# Altium schematic sheet PRODUCT_SchematicSheet.SchDoc(PRODUCT_SchematicSheet)
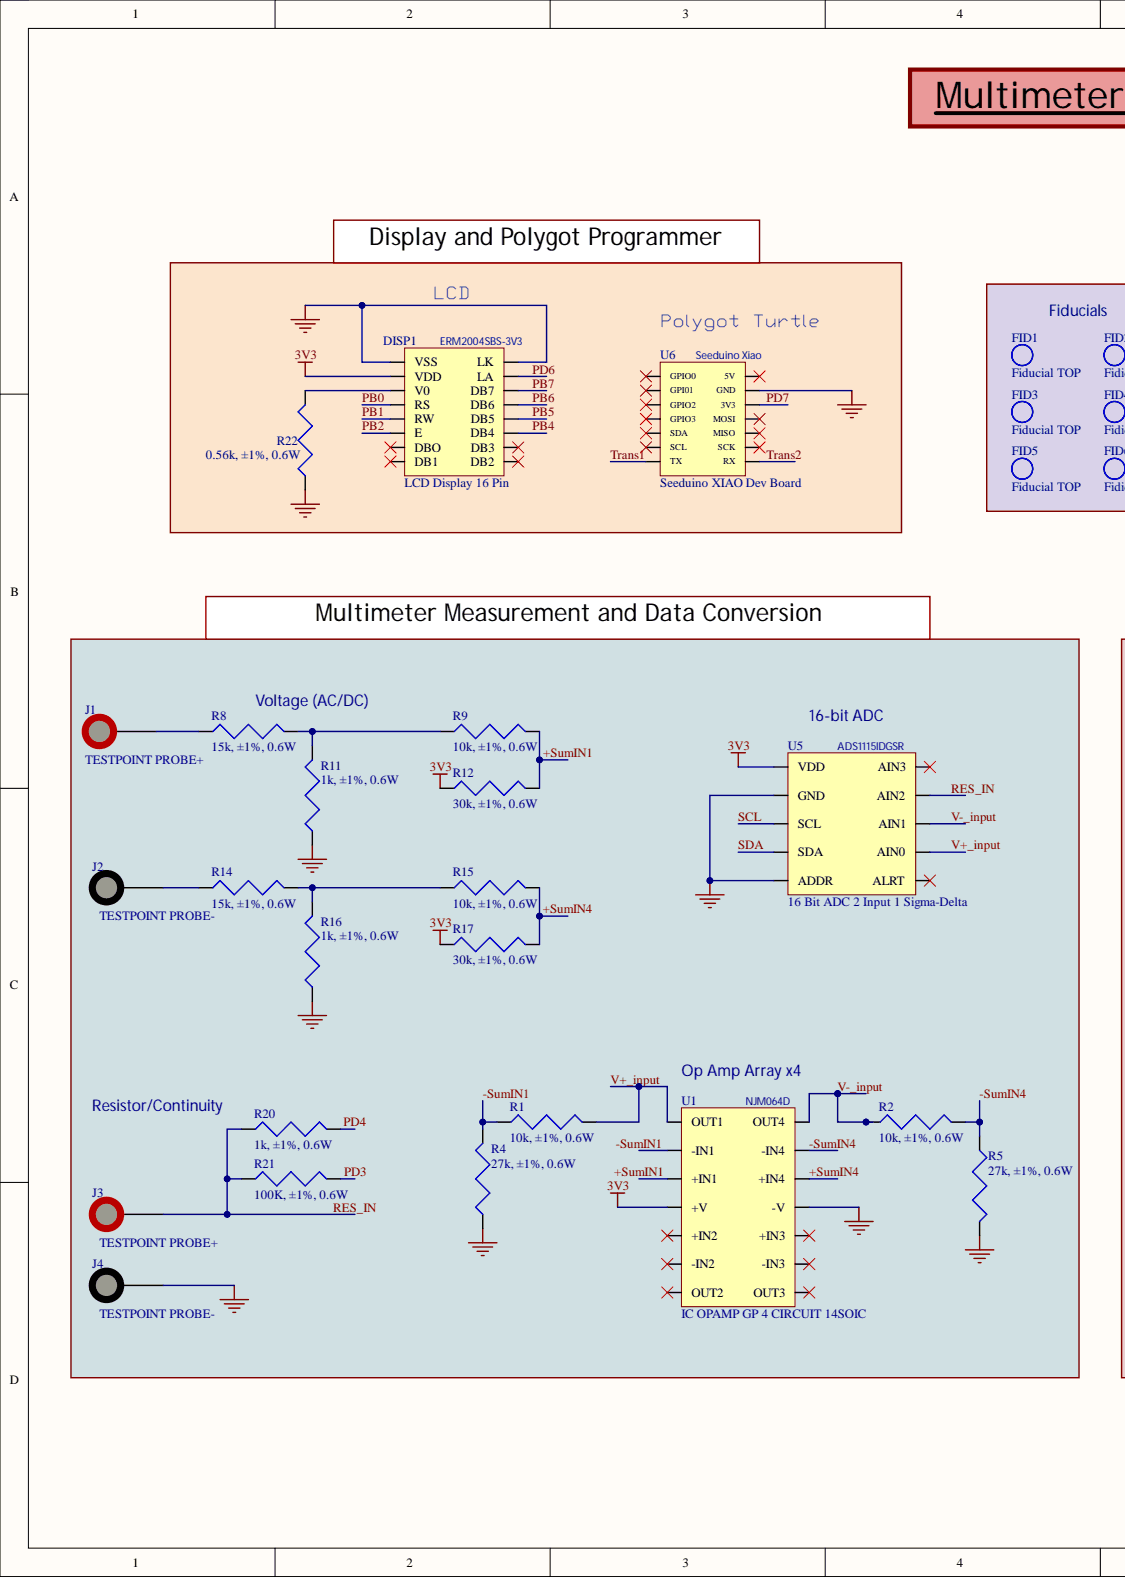
[diagram p0: PRODUCT_SchematicSheet.SchDoc, left]
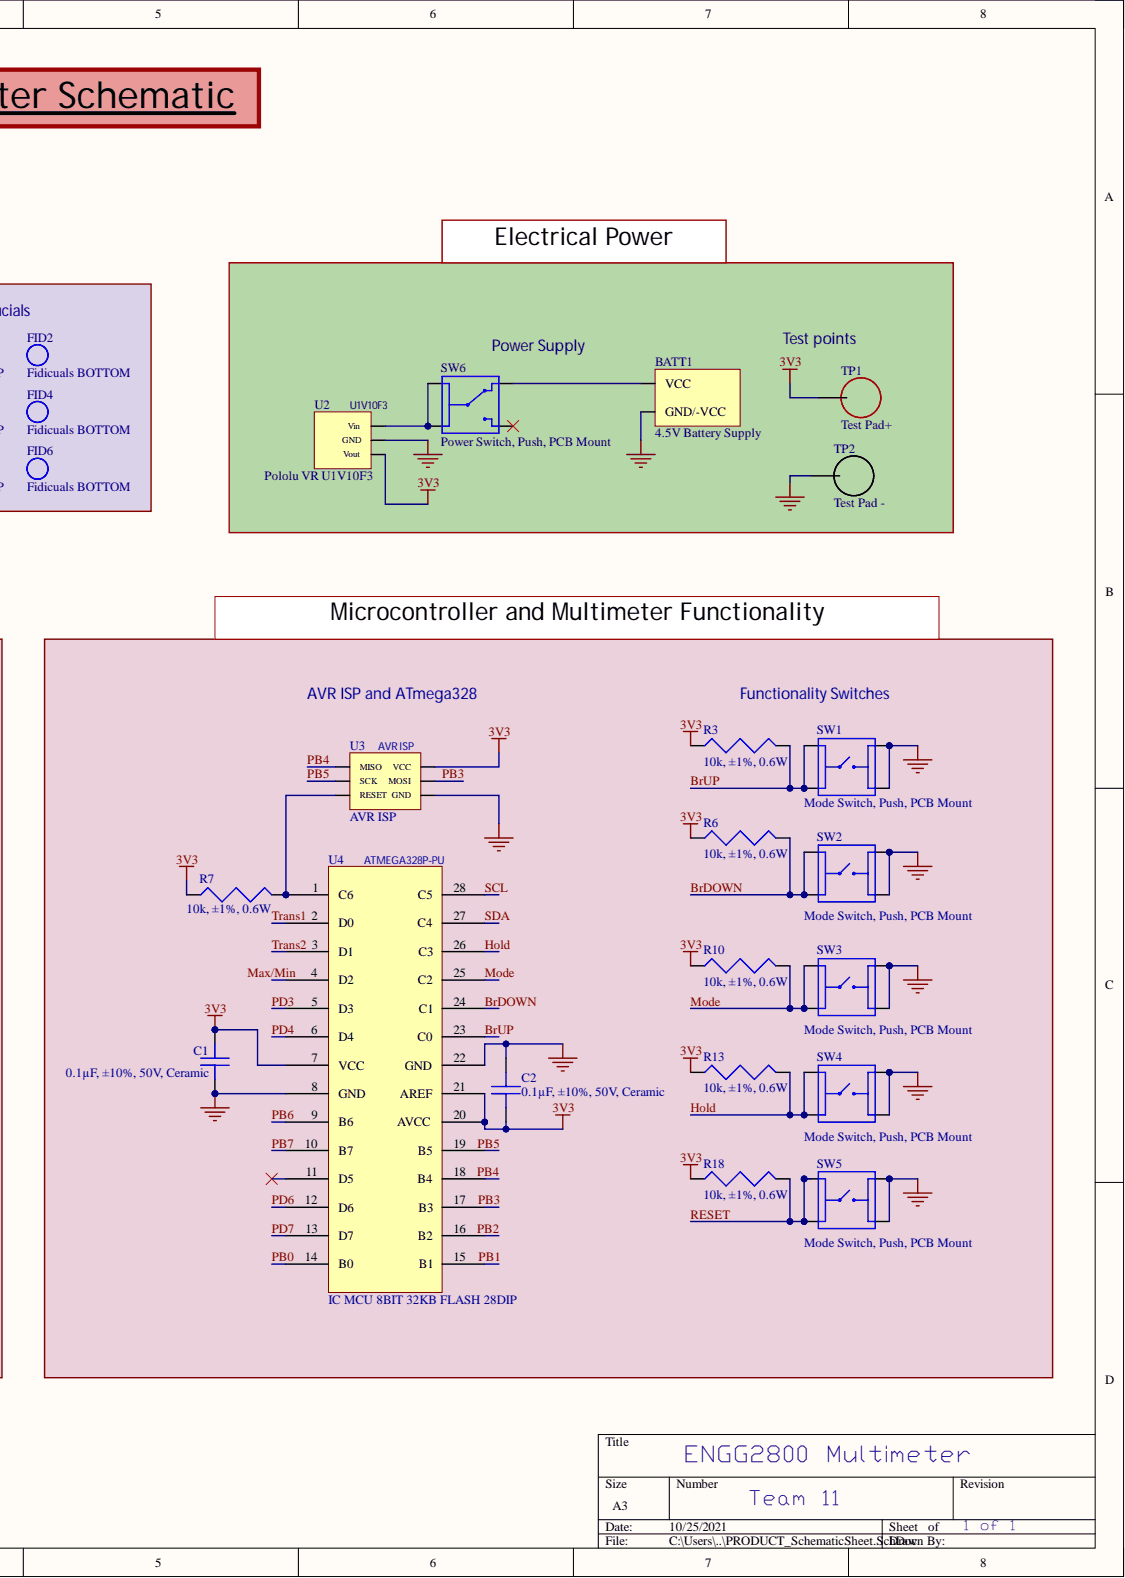
[diagram p1: PRODUCT_SchematicSheet.SchDoc, right]

## Parts
BATT1  4.5V Battery Supply  [JST PH 4.5V Battery Connector]
C1  0.1µF, ±10%, 50V, Ceramic  Resistor Type: Ceramic  [0.1uF Non - Polarized]
C2  0.1µF, ±10%, 50V, Ceramic  [0.1uF Non - Polarized]
DISP1  LCD Display 16 Pin  [LCD Display]
FID1  Fiducial TOP  [Top Fidicual]
FID2  Fidicuals BOTTOM  [Bottom Fiducial]
FID3  Fiducial TOP  [Top Fidicual]
FID4  Fidicuals BOTTOM  [Bottom Fiducial]
FID5  Fiducial TOP  [Top Fidicual]
FID6  Fidicuals BOTTOM  [Bottom Fiducial]
J1  TESTPOINT PROBE+  [4mm Banana Socket (+VE)]
J2  TESTPOINT PROBE-  [4mm Banana Socket (-VE)]
J3  TESTPOINT PROBE+  [4mm Banana Socket (+VE)]
J4  TESTPOINT PROBE-  [4mm Banana Socket (-VE)]
R1  10k, ±1%, 0.6W  [10k (Voltage and ATmega)]
R2  10k, ±1%, 0.6W  [10k (Voltage and ATmega)]
R3  10k, ±1%, 0.6W  [10k (Voltage and ATmega)]
R4  27k, ±1%, 0.6W  [27k (Voltage)]
R5  27k, ±1%, 0.6W  [27k (Voltage)]
R6  10k, ±1%, 0.6W  [10k (Voltage and ATmega)]
R7  10k, ±1%, 0.6W  [10k (Voltage and ATmega)]
R8  15k, ±1%, 0.6W  [15k (Voltage)]
R9  10k, ±1%, 0.6W  [10k (Voltage and ATmega)]
R10  10k, ±1%, 0.6W  [10k (Voltage and ATmega)]
R11  1k, ±1%, 0.6W  [1k (Voltage)]
R12  30k, ±1%, 0.6W  [30k (Voltage)]
R13  10k, ±1%, 0.6W  [10k (Voltage and ATmega)]
R14  15k, ±1%, 0.6W  [15k (Voltage)]
R15  10k, ±1%, 0.6W  [10k (Voltage and ATmega)]
R16  1k, ±1%, 0.6W  [1k (Voltage)]
R17  30k, ±1%, 0.6W  [30k (Voltage)]
R18  10k, ±1%, 0.6W  [10k (Voltage and ATmega)]
R20  1k, ±1%, 0.6W  [1k (Voltage)]
R21  100K, ±1%, 0.6W  [100k (Resistive Circuit)]
R22  0.56k, ±1%, 0.6W  [0.56k Axial]
SW1  Mode Switch, Push, PCB Mount  [Tactile Switch]
SW2  Mode Switch, Push, PCB Mount  [Tactile Switch]
SW3  Mode Switch, Push, PCB Mount  [Tactile Switch]
SW4  Mode Switch, Push, PCB Mount  [Tactile Switch]
SW5  Mode Switch, Push, PCB Mount  [Tactile Switch]
SW6  Power Switch, Push, PCB Mount  [Tactile Switch]
TP1  Test Pad+  [Test Pad+]
TP2  Test Pad -  [Test Pad-]
U1  IC OPAMP GP 4 CIRCUIT 14SOIC  [OP AMP 4IC]
U2  Pololu VR U1V10F3  [Polulu VR U1V10F3]
U3  AVR ISP  [AVR ISP]
U4  IC MCU 8BIT 32KB FLASH 28DIP  Memory Size: 32KB  Memory Type: FLASH  [ATmega328P-PU]
U5  16 Bit ADC 2 Input 1 Sigma-Delta  [16-Bit ADC]
U6  Seeduino XIAO Dev Board  [Polygot Seeduino]

## Nets
3V3: C1.2 C2.1 DISP1.2(VDD) R3.1 R6.1 R7.1 R10.1 R12.1 R13.1 R17.1 R18.1 TP1.1 U1.4(+V) U2.3 U3.6(VCC) U4.7(VCC) U4.20(AVCC) U4.21(AREF) U5.1(VDD)
GND: BATT1.2 C1.1 C2.2 DISP1.1(VSS) DISP1.16(LK) J4.1 R4.1 R5.1 R11.1 R16.1 R22.1 SW1.3 SW1.4 SW2.3 SW2.4 SW3.3 SW3.4 SW4.3 SW4.4 SW5.3 SW5.4 TP2.1 U1.11(-V) U2.2 U3.4(GND) U4.8(GND) U4.22(GND) U5.2(GND) U5.5(ADDR) U6.13(GND)
+SumIN1: R9.2 R12.2 U1.3(+IN1)
+SumIN4: R15.2 R17.2 U1.12(+IN4)
-SumIN1: R1.1 R4.2 U1.2(-IN1)
-SumIN4: R2.1 R5.2 U1.13(-IN4)
BrDOWN: R6.2 SW2.1 SW2.2 U4.24(C1)
BrUP: R3.2 SW1.1 SW1.2 U4.23(C0)
Hold: R13.2 SW4.1 SW4.2 U4.26(C3)
Max/Min: U4.4(D2)
Mode: R10.2 SW3.1 SW3.2 U4.25(C2)
PB0: DISP1.4(RS) U4.14(B0)
PB1: DISP1.5(RW) U4.15(B1)
PB2: DISP1.6(E) U4.16(B2)
PB3: U3.5(MOSI) U4.17(B3)
PB4: DISP1.11(DB4) U3.1(MISO) U4.18(B4)
PB5: DISP1.12(DB5) U3.2(SCK) U4.19(B5)
PB6: DISP1.13(DB6) U4.9(B6)
PB7: DISP1.14(DB7) U4.10(B7)
PD3: R21.2 U4.5(D3)
PD4: R20.1 U4.6(D4)
PD6: DISP1.15(LA) U4.12(D6)
PD7: U4.13(D7) U6.12(3V3)
RES_IN: J3.1 R20.2 R21.1 U5.9(AIN2)
RESET: R18.2 SW5.1 SW5.2
SCL: U4.28(C5) U5.3(SCL)
SDA: U4.27(C4) U5.4(SDA)
Trans1: U4.2(D0) U6.7(TX)
Trans2: U4.3(D1) U6.8(RX)
V+_input: R1.2 U1.1(OUT1) U5.7(AIN0)
V-_input: R2.2 U1.14(OUT4) U5.8(AIN1)
NetBATT1_1: BATT1.1 SW6.4
NetDISP1_3: DISP1.3(V0) R22.2
NetJ1_1: J1.1 R8.1
NetJ2_1: J2.1 R14.1
NetR7_2: R7.2 U3.3(RESET) U4.1(C6)
NetR8_2: R8.2 R9.1 R11.2
NetR14_2: R14.2 R15.1 R16.2
NetSW6_1: SW6.1 SW6.2 U2.1

Not connected: DISP1 7(DBO) 8(DB1) 9(DB2) 10(DB3); SW6 3; U1 5(+IN2) 6(-IN2) 7(OUT2) 8(OUT3) 9(-IN3) 10(+IN3); U4 11(D5); U5 6(ALRT) 10(AIN3); U6 1(GPIO0) 2(GPI01) 3(GPIO2) 4(GPIO3) 5(SDA) 6(SCL) 9(SCK) 10(MISO) 11(MOSI) 14(5V)

## Library data of the parts
BATT1 = Power Header: Component Kind: Standard; Description: 4.5V Battery Supply; Library Name: PRODUCT_SchematicLib.SchLib
C1 C2 = CC0402ZRY5V7BB104: Capacitor 0.1uF0.56k, ±1%, 0.6W; Manufacturer: Yageo; Capacitance: 100nF; Dielectric: Y5V; Insulation Resistance: 100GR; Tolerance: 80%; Voltage Rating: 16V; Case Code (Imperial): 0402; Case Code (Metric): 1005; Case/Package: 0402; Category: Ceramic Capacitors; Component Kind: Standard; ComponentLink1Description: https://datasheet.ciiva.com/143/mlccorderingcode-143948.pdf?src-supplier=Digi-Key; ComponentLink1URL: https://datasheet.ciiva.com/143/mlccorderingcode-143948.pdf?src-supplier=Digi-Key; ComponentLink2Description: https://datasheet.ciiva.com/143/mlccorderingcode-143947.pdf?src-supplier=Digi-Key; ComponentLink2URL: https://datasheet.ciiva.com/143/mlccorderingcode-143947.pdf?src-supplier=Digi-Key; ComponentLink3Description: https://datasheet.ciiva.com/143/mlccorderingcode-143949.pdf?src-supplier=Digi-Key; ComponentLink3URL: https://datasheet.ciiva.com/143/mlccorderingcode-143949.pdf?src-supplier=Digi-Key; ComponentLink4Description: https://datasheet.ciiva.com/26862/getdatasheetpartid-403483-26862665.pdf?src-supplier=Verical; ComponentLink4URL: https://datasheet.ciiva.com/26862/getdatasheetpartid-403483-26862665.pdf?src-supplier=Verical; ComponentLink5Description: https://datasheet.ciiva.com/11586/upy-gphc-y5v-6-3v-to-50v-5-11586519.pdf?src-supplier=Avnet; ComponentLink5URL: https://datasheet.ciiva.com/11586/upy-gphc-y5v-6-3v-to-50v-5-11586519.pdf?src-supplier=Avnet; ComponentLink6Description: https://datasheet.ciiva.com/13386/upy-gphc-y5v-6-3v-to-50v-5-13386335.pdf?src-supplier=Mouser; ComponentLink6URL: https://datasheet.ciiva.com/13386/upy-gphc-y5v-6-3v-to-50v-5-13386335.pdf?src-supplier=Mouser; ComponentLink7Description: https://datasheet.ciiva.com/7978/1132289-7978122.pdf?src-supplier=Element14; ComponentLink7URL: https://datasheet.ciiva.com/7978/1132289-7978122.pdf?src-supplier=Element14; ComponentLink8Description: https://datasheet.ciiva.com/28450/1132289-28450424.pdf?src-supplier=Element14; ComponentLink8URL: https://datasheet.ciiva.com/28450/1132289-28450424.pdf?src-supplier=Element14; ComponentLink9Description: https://datasheet.ciiva.com/8033/1132289-8033645.pdf?src-supplier=Element14; ComponentLink9URL: https://datasheet.ciiva.com/8033/1132289-8033645.pdf?src-supplier=Element14; ComponentLink10Description: https://datasheet.ciiva.com/8033/1132289-8033647.pdf?src-supplier=Element14; ComponentLink10URL: https://datasheet.ciiva.com/8033/1132289-8033647.pdf?src-supplier=Element14; Depth: 0.5mm; Halogen Free: Halogen Free; Height: 0.5mm; Lead Free: Lead Free; Length: 1mm; Manufacturer Part Number: CC0402ZRY5V7BB104; Max Operating Temperature: 85°C; Min Operating Temperature: -30°C; Mount: Surface Mount; Package Quantity: 10000; Packaging: Tape and Reel; Pricing 1: 1=0.10000, 10=0.01900, 50=0.01040, 100=0.00860, 500=0.00612, 1000=0.00481, 2500=0.00437, 5000=0.00402 (USD); Radiation Hardening: No; REACH SVHC: No SVHC; RoHS: Yes; RoHS Compliant: Yes; Series: CC; Stock 1: 1328608; Supplier 1: Digi-Key; Supplier Part Number 1: 311-1047-1-ND; Termination: SMD/SMT; Thickness: 0.022inch; Voltage Rating (DC): 16V; Width: 0.02inch; Library Name: PRODUCT_SchematicLib.SchLib
  C2: Resistor Type: Ceramic
DISP1 = LCD: ERM2004SBS-3V3; Component Kind: Standard; Library Name: PRODUCT_SchematicLib.SchLib
FID1 FID3 FID5 = Top Fiducials: Component Kind: Standard; Library Name: PRODUCT_SchematicLib.SchLib
FID2 FID4 FID6 = Bottom Fiducials: Component Kind: Standard; Library Name: PRODUCT_SchematicLib.SchLib
R1-R3 R6 R7 R9 R10 R13 R15 R18 = RE1206FRE0710KL: Resistor 10k (Voltage); Manufacturer: Yageo; Max Power Dissipation: 250mW; Resistance: 10kR; Temperature Coefficient: 50ppm/°C; Case/Package: 1206; Category: Chip SMD Resistors; Component Kind: Standard; ComponentLink1Description: http://www.yageo.com/NewPortal/yageodocoutput?fileName=/pdf/R-Chip/PYu-RE_105_RoHS_L_4.pdf&src-supplier=Digi-Key; ComponentLink1URL: http://www.yageo.com/NewPortal/yageodocoutput?fileName=/pdf/R-Chip/PYu-RE_105_RoHS_L_4.pdf&src-supplier=Digi-Key; Features: Moisture Resistant; Height: 0.026inch; Length: 0.122inch; Manufacturer Part Number: RE1206FRE0710KL; Max Operating Temperature: 155°C; Min Operating Temperature: -55°C; Number of Terminations: 2; Packaging: Tape and Reel; Pricing 1: 1=0.10000, 10=0.06800, 100=0.02750, 1000=0.01234, 2500=0.01071 (USD); Resistor Type: Thick Film; RoHS: Yes; Stock 1: 4506; Supplier 1: Digi-Key; Supplier Part Number 1: 13-RE1206FRE0710KLCT-ND; Tolerance: 1%; Width: 0.063inch; Library Name: PRODUCT_SchematicLib.SchLib
R4 R5 = Resistor 27k: Resistor 27k Ohms (Voltage); Manufacturer: Stackpole Electronics; Manufacturer Part Number: RMCF0603FT140K; Max Power Dissipation: 100mW; Resistance: 140kR; Temperature Coefficient: 100ppm/°C; Case Code (Imperial): 0603; Case Code (Metric): 1608; Case/Package: 0603; Category: Chip SMD Resistors; Component Kind: Standard; ComponentLink1Description: https://www.seielect.com/catalog/SEI-RMCF_RMCP.pdf?src-supplier=Avnet; ComponentLink1URL: https://www.seielect.com/catalog/SEI-RMCF_RMCP.pdf?src-supplier=Avnet; ComponentLink2Description: https://www.seielect.com/catalog/SEI-Packaging.pdf?src-supplier=Digi-Key; ComponentLink2URL: https://www.seielect.com/catalog/SEI-Packaging.pdf?src-supplier=Digi-Key; ComponentLink3Description: http://www.seielect.com/Catalog/SEI-RMC.pdf?src-supplier=Future+Electronics; ComponentLink3URL: http://www.seielect.com/Catalog/SEI-RMC.pdf?src-supplier=Future+Electronics; Contact Plating: Tin,Matte; Features: Automotive AEC-Q200; Height: 0.022inch; Lead Free: Lead Free; Length: 0.061inch; Max Operating Temperature: 155°C; Min Operating Temperature: -55°C; Mount: Surface Mount; Number of Terminations: 2; Packaging: Tape and Reel; Power Rating: 100mW; Pricing 1: 1=0.10000, 10=0.01700, 100=0.00680, 1000=0.00305, 2500=0.00264 (USD); Radiation Hardening: No; Resistor Type: Thick Film; RoHS: Yes; RoHS Compliant: Yes; Series: RMCF; Size Code: 0603; Stock 1: 48551; Supplier 1: Digi-Key; Supplier Part Number 1: RMCF0603FT140KCT-ND; Tolerance: 1%; Width: 0.031inch; Library Name: PRODUCT_SchematicLib.SchLib
R8 R14 = Resistor 15k: Resistor 15k Ohms (Voltage); Manufacturer: Yageo; Manufacturer Part Number: RC0603FR-073KL; Max Power Dissipation: 100mW; Resistance: 3kR; Temperature Coefficient: 100ppm/°C; Voltage Rating: 50V; Case Code (Imperial): 0603; Case Code (Metric): 1608; Case/Package: 0603; Category: Chip SMD Resistors; Component Kind: Standard; ComponentLink1Description: http://components.arrow.com/part/dataview/0323_00200942?src-supplier=Arrow+Europe; ComponentLink1URL: http://components.arrow.com/part/dataview/0323_00200942?src-supplier=Arrow+Europe; ComponentLink2Description: http://www.mouser.com/ds/2/447/RC0603-272842.pdf?src-supplier=Mouser; ComponentLink2URL: http://www.mouser.com/ds/2/447/RC0603-272842.pdf?src-supplier=Mouser; ComponentLink3Description: http://www.farnell.com/datasheets/2167052.pdf?src-supplier=Farnell; ComponentLink3URL: http://www.farnell.com/datasheets/2167052.pdf?src-supplier=Farnell; ComponentLink4Description: https://www.verical.com/server-webapp/html/getDatasheet?partID=135099&src-supplier=Verical; ComponentLink4URL: https://www.verical.com/server-webapp/html/getDatasheet?partID=135099&src-supplier=Verical; ComponentLink5Description: http://www.farnell.com/datasheets/2167052.pdf?src-supplier=Element14; ComponentLink5URL: http://www.farnell.com/datasheets/2167052.pdf?src-supplier=Element14; ComponentLink6Description: http://www.farnell.com/datasheets/1868787.pdf?src-supplier=Newark; ComponentLink6URL: http://www.farnell.com/datasheets/1868787.pdf?src-supplier=Newark; ComponentLink7Description: http://www.yageo.com/documents/recent/PYu-RC0603_51_RoHS_L_7.pdf?src-supplier=Future+Electronics; ComponentLink7URL: http://www.yageo.com/documents/recent/PYu-RC0603_51_RoHS_L_7.pdf?src-supplier=Future+Electronics; ComponentLink8Description: http://www.yageo.com/documents/recent/PYu-RC_Group_51_RoHS_L_9.pdf?src-supplier=Digi-Key; ComponentLink8URL: http://www.yageo.com/documents/recent/PYu-RC_Group_51_RoHS_L_9.pdf?src-supplier=Digi-Key; ComponentLink9Description: http://www.yageo.com/documents/recent/PYu-RC_Group_51_RoHS_L_10.pdf?src-supplier=Digi-Key; ComponentLink9URL: http://www.yageo.com/documents/recent/PYu-RC_Group_51_RoHS_L_10.pdf?src-supplier=Digi-Key; Construction: Rectangular; Depth: 0.8mm; Features: Moisture Resistant; Lead Free: Lead Free; Length: 1.6mm; Max Operating Temperature: 155°C; Military Standard: Not; Min Operating Temperature: -55°C; Number of Pins: 2; Number of Terminations: 2; Packaging: Tape and Reel; Power Rating: 100mW; Pricing 1: 1=0.10000, 10=0.02400, 100=0.00970, 1000=0.00436, 2500=0.00378 (USD); REACH SVHC: No SVHC; Resistance Tolerance: 1%; Resistor Type: Thick Film; RoHS: Yes; RoHS Compliant: Yes; Series: RC; Size Code: 0603; Stock 1: 210957; Supplier 1: Digi-Key; Supplier Part Number 1: 311-3.00KHRCT-ND; Termination: SMD/SMT; Tolerance: 1%; Voltage Rating (DC): 50V; Width: 800um; Library Name: PRODUCT_SchematicLib.SchLib
R11 R16 R20 = RE0603FRE071KL: Resistor 1k Ohms; Manufacturer: Yageo; Max Power Dissipation: 100mW; Resistance: 1kR; Temperature Coefficient: 50ppm/°C; Case/Package: 0603; Category: Chip SMD Resistors; Component Kind: Standard; ComponentLink1Description: http://www.yageo.com/NewPortal/yageodocoutput?fileName=/pdf/R-Chip/PYu-RE_105_RoHS_L_4.pdf&src-supplier=Digi-Key; ComponentLink1URL: http://www.yageo.com/NewPortal/yageodocoutput?fileName=/pdf/R-Chip/PYu-RE_105_RoHS_L_4.pdf&src-supplier=Digi-Key; Features: Moisture Resistant; Height: 0.022inch; Length: 0.063inch; Manufacturer Part Number: RE0603FRE071KL; Max Operating Temperature: 155°C; Min Operating Temperature: -55°C; Number of Terminations: 2; Packaging: Tape and Reel; Pricing 1: 1=0.10000, 10=0.03600, 100=0.01450, 1000=0.00649, 2500=0.00563 (USD); Resistor Type: Thick Film; RoHS: Yes; RoHS Compliant: Yes; Stock 1: 2276; Supplier 1: Digi-Key; Supplier Part Number 1: 13-RE0603FRE071KLCT-ND; Tolerance: 1%; Width: 0.032inch; Library Name: PRODUCT_SchematicLib.SchLib
R12 R17 = Resistor 30k: Resistor 30k Ohms (Voltage); Component Kind: Standard; Library Name: PRODUCT_SchematicLib.SchLib
R21 = Resistor 100k: Resistor 100k ohms (Resistance Circuit); Component Kind: Standard; Library Name: PRODUCT_SchematicLib.SchLib
R22 = Resistor 0.56k: Resistor 0.56k Ohms (LCD); Component Kind: Standard; Library Name: PRODUCT_SchematicLib.SchLib
SW1-SW5 = Mode Button: Component Kind: Standard; Library Name: PRODUCT_SchematicLib.SchLib
SW6 = Power Switch: Component Kind: Standard; Library Name: PRODUCT_SchematicLib.SchLib
TP2 = Test Pad-: Component Kind: Standard; Library Name: PRODUCT_SchematicLib.SchLib
U1 = LM2902LVIDR: QUAD CHANNEL AMP; Manufacturer: Texas Instruments; Gain Bandwidth Product: 1MHz; Input Bias Current: 15pA; Input Offset Voltage (Vos): 1mV; Number of Circuits: 4; Operating Supply Current: 90uA; Output Current per Channel: 40mA; Slew Rate: 1.5V/µs; Case/Package: SOIC; Category: Amplifiers - Op Amps, Buffer, Instrumentation; Component Kind: Standard; ComponentLink1Description: http://www.ti.com/lit/gpn/lm2902lv?src-supplier=Digi-Key; ComponentLink1URL: http://www.ti.com/lit/gpn/lm2902lv?src-supplier=Digi-Key; ComponentLink2Description: http://www.ti.com/general/docs/suppproductinfo.tsp?distId=10&amp;gotoUrl=http%3A%2F%2Fwww.ti.com%2Flit%2Fgpn%2Flm2902lv&src-supplier=Digi-Key; ComponentLink2URL: http://www.ti.com/general/docs/suppproductinfo.tsp?distId=10&amp;gotoUrl=http%3A%2F%2Fwww.ti.com%2Flit%2Fgpn%2Flm2902lv&src-supplier=Digi-Key; Library Name: PRODUCT_SchematicLib.SchLib; Manufacturer Part Number: LM2902LVIDR; Max Operating Temperature: 125°C; Min Operating Temperature: -40°C; Mount: Surface Mount; Number of Pins: 14; Packaging: Tape and Reel; Pricing 1: 1=0.37000, 10=0.29600, 25=0.27080, 100=0.20160, 250=0.18272, 500=0.15120, 1000=0.11340 (USD); RoHS: Yes; RoHS Compliant: Yes; Stock 1: 1440; Supplier 1: Digi-Key; Supplier Part Number 1: 296-51255-1-ND
U2 = Polulu VR: Pololu 3.3V Step-Up Voltage Regulator U1V10F3; Component Kind: Standard; Library Name: PRODUCT_SchematicLib.SchLib
U3 = AVR ISP: ISP Programmer; Component Kind: Standard; Library Name: PRODUCT_SchematicLib.SchLib
U4 = ATMEGA328P-PU: Manufacturer: Microchip / Atmel; Core Architecture: AVR; Data Bus Width: 8b; Frequency: 20MHz; Interface: I2C,SPI,UART,USART,2-Wire; Max Supply Voltage: 5.5V; Min Supply Voltage: 1.8V; Number of ADC Channels: 6; Number of I2C Channels: 1; Number of I/Os: 23; Number of Timers/Counters: 3; Oscillator Type: Internal; Peripherals: Brown-out Detect/Reset,POR,PWM,WDT; RAM Size: 2KB; Watchdog Timer: Yes; Case/Package: PDIP; Category: Microcontrollers; Component Kind: Standard; ComponentLink1Description: https://datasheet.ciiva.com/19457/2139111-19457070.pdf?src-supplier=Jameco; ComponentLink1URL: https://datasheet.ciiva.com/19457/2139111-19457070.pdf?src-supplier=Jameco; ComponentLink2Description: https://datasheet.ciiva.com/1157/1684409-1157696.pdf?src-supplier=Element14; ComponentLink2URL: https://datasheet.ciiva.com/1157/1684409-1157696.pdf?src-supplier=Element14; ComponentLink3Description: https://datasheet.ciiva.com/20168/73-4283-20168380.pdf?src-supplier=Rapid+Electronics; ComponentLink3URL: https://datasheet.ciiva.com/20168/73-4283-20168380.pdf?src-supplier=Rapid+Electronics; ComponentLink4Description: https://datasheet.ciiva.com/29119/155197-da-01-en-microcontr-atmega328p-pu-8bit-pdip-28-29119593.pdf?src-supplier=Conrad; ComponentLink4URL: https://datasheet.ciiva.com/29119/155197-da-01-en-microcontr-atmega328p-pu-8bit-pdip-28-29119593.pdf?src-supplier=Conrad; ComponentLink5Description: https://datasheet.ciiva.com/26818/atmega328p-pu-26818578.pdf?src-supplier=IIIC; ComponentLink5URL: https://datasheet.ciiva.com/26818/atmega328p-pu-26818578.pdf?src-supplier=IIIC; ComponentLink6Description: https://datasheet.ciiva.com/15213/getdatasheetpartid-318533-15213133.pdf?src-supplier=Verical; ComponentLink6URL: https://datasheet.ciiva.com/15213/getdatasheetpartid-318533-15213133.pdf?src-supplier=Verical; Contact Plating: Tin,Matte; Description: ATmega328P-PU; Height: 3.28mm; Lead Free: Lead Free; Length: 37.4mm; Manufacturer Part Number: ATMEGA328P-PU; Max Frequency: 20MHz; Max Operating Temperature: 85°C; Min Operating Temperature: -40°C; Mount: Through Hole; Number of Pins: 28; Number of Programmable I/O: 23; Number of PWM Channels: 6; Number of SPI Channels: 1; Package Quantity: 14; Packaging: Rail/Tube; Pricing 1: 10=5.76000, 50=5.56000, 100=5.36000, 500=5.18500 (AUD); Radiation Hardening: No; REACH SVHC: No SVHC; RoHS: Yes; RoHS Compliant: Yes; Series: AVR® ATmega; Stock 1: 990; Supplier 1: RSComponents; Supplier Part Number 1: 1310276P; Width: 6.76mm; Library Name: PRODUCT_SchematicLib.SchLib
U5 = ADS1115IDGSR: 16 - bit ADC; Manufacturer: Texas Instruments; Conversion Rate: 0.86KS/s; Integral Nonlinearity (INL): 1LSB; Interface: I2C; Max Supply Voltage: 5.5V; Min Supply Voltage: 2V; Number of A/D Converters: 1; Number of Bits: 16; Number of Inputs: 4; Polarity: Bipolar; Power Dissipation: 900uW; Resolution: 16b; Sampling Rate: 860S/s; Supply Type: Single; Case/Package: VSSOP; Category: Analog to Digital Converters (ADCs); Component Kind: Standard; ComponentLink1Description: https://datasheet.ciiva.com/4031/47725463s8438921n7713-4031028.pdf?src-supplier=Arrow+Electronics; ComponentLink1URL: https://datasheet.ciiva.com/4031/47725463s8438921n7713-4031028.pdf?src-supplier=Arrow+Electronics; ComponentLink2Description: https://datasheet.ciiva.com/25993/sbas444b-25993205.pdf?src-supplier=Avnet+Europe; ComponentLink2URL: https://datasheet.ciiva.com/25993/sbas444b-25993205.pdf?src-supplier=Avnet+Europe; ComponentLink3Description: https://datasheet.ciiva.com/1304/ads1113-1304266.pdf?src-supplier=Digi-Key; ComponentLink3URL: https://datasheet.ciiva.com/1304/ads1113-1304266.pdf?src-supplier=Digi-Key; Contact Plating: Gold; Lead Free: Lead Free; Manufacturer Part Number: ADS1115IDGSR; Max Operating Temperature: 125°C; Max Supply Voltage (DC): 5.5V; Min Operating Temperature: -40°C; Min Supply Voltage (DC): 2V; Number of Converters: 1; Number of Pins: 10; Package Quantity: 2500; Packaging: Tape and Reel; Pricing 1: 1=6.43000, 10=5.77200, 25=5.45720, 100=4.72930, 250=4.48676, 500=4.02596, 1000=4.00400 (USD); Radiation Hardening: No; REACH SVHC: No SVHC; RoHS: Yes; RoHS Compliant: Yes; Series: -; Supplier 1: Digi-Key; Supplier Part Number 1: 296-38849-1-ND; Library Name: PRODUCT_SchematicLib.SchLib
U6 = Seediuno - Polygot: Polygot Seeduino; Component Kind: Standard; Library Name: PRODUCT_SchematicLib.SchLib
J1 J3 = TESTPOINT PROBE+: Component Kind: Standard; Library Name: PRODUCT_SchematicLib.SchLib
J2 J4 = TESTPOINT PROBE-: Component Kind: Standard; Library Name: PRODUCT_SchematicLib.SchLib
TP1 = Test Pad+: Component Kind: Standard; Library Name: PRODUCT_SchematicLib.SchLib
